# Altium schematic sheet BTT_M157_MOS_V0.3.SchDoc(BTT_M157_MOS_V0.3)
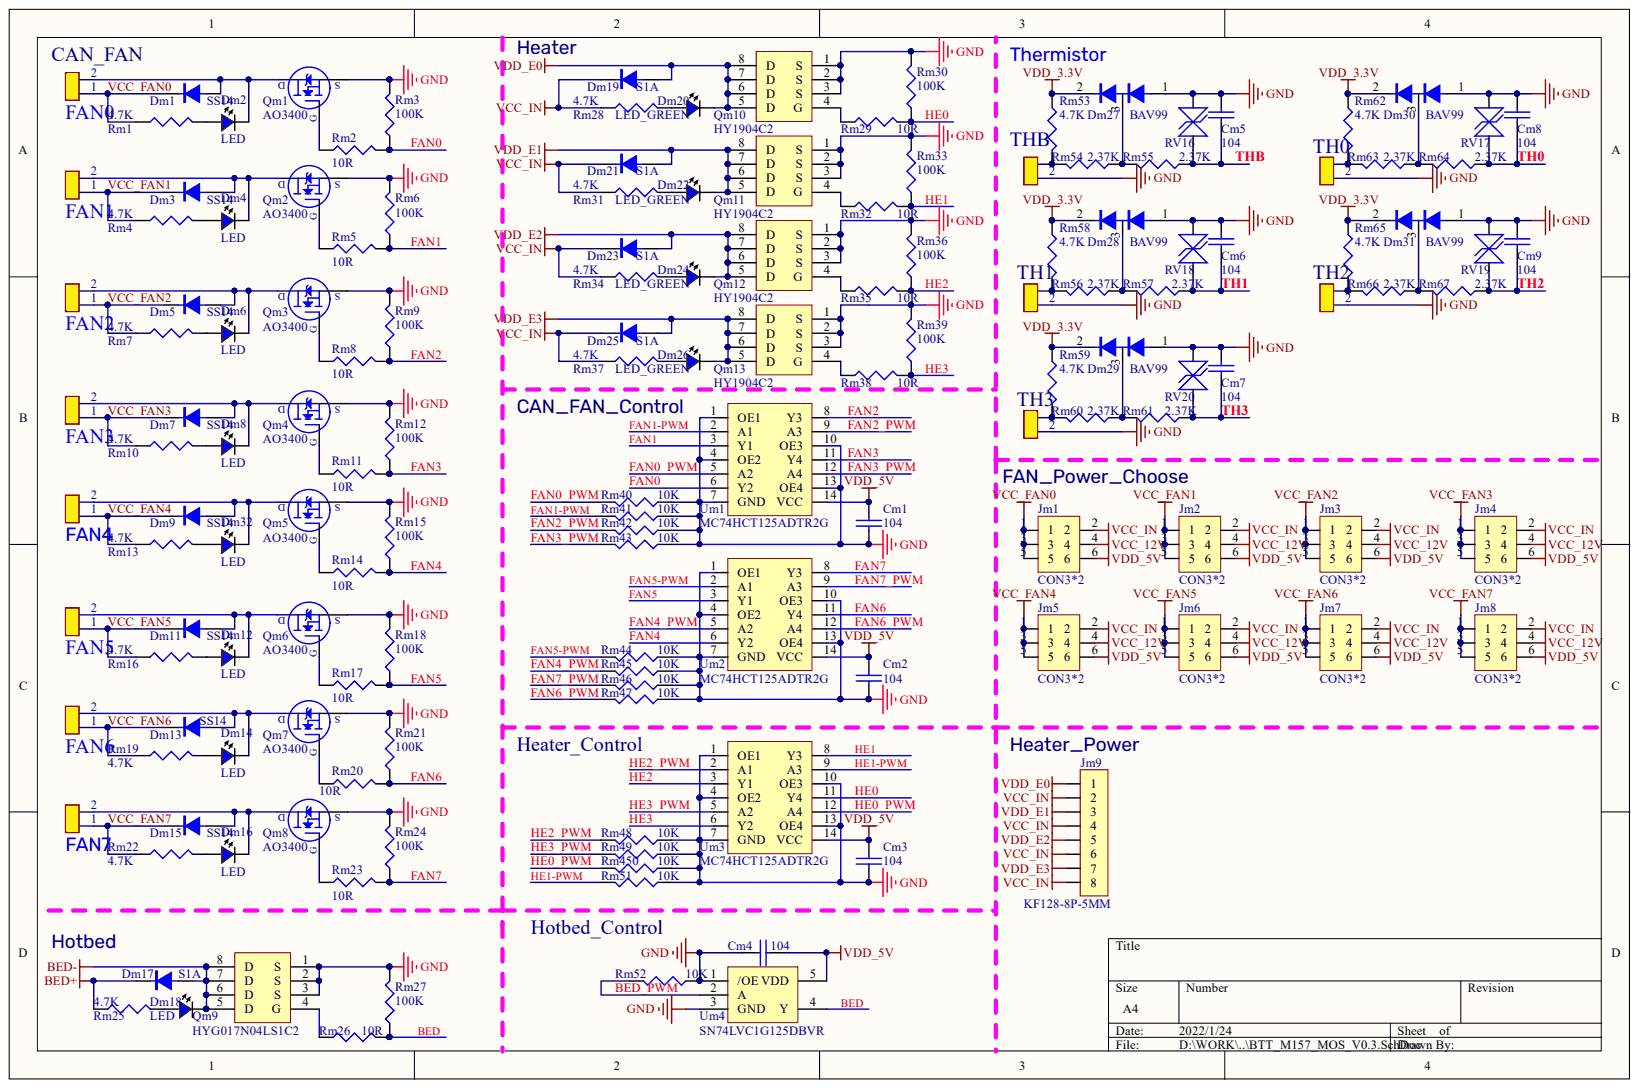
[diagram p0: BTT_M157_MOS_V0.3.SchDoc]

## Parts
Cm1  104  [0603c]
Cm2  104  [0603c]
Cm3  104  [0603c]
Cm4  104  [0603c]
Cm5  104  [0603c]
Cm6  104  [0603c]
Cm7  104  [0603c]
Cm8  104  [0603c]
Cm9  104  [0603c]
Dm1  SS14  [SOD-123FL]
Dm2  LED  [LED-0603-green]
Dm3  SS14  [SOD-123FL]
Dm4  LED  [LED-0603-green]
Dm5  SS14  [SOD-123FL]
Dm6  LED  [LED-0603-green]
Dm7  SS14  [SOD-123FL]
Dm8  LED  [LED-0603-green]
Dm9  SS14  [SOD-123FL]
Dm11  SS14  [SOD-123FL]
Dm12  LED  [LED-0603-green]
Dm13  SS14  [SOD-123FL]
Dm14  LED  [LED-0603-green]
Dm15  SS14  [SOD-123FL]
Dm16  LED  [LED-0603-green]
Dm17  S1A  [DO214AC(SMA)]
Dm18  LED  [LED-0603-green]
Dm19  S1A  [DO214AC(SMA)]
Dm20  LED_GREEN  [LED-0603-green]
Dm21  S1A  [DO214AC(SMA)]
Dm22  LED_GREEN  [LED-0603-green]
Dm23  S1A  [DO214AC(SMA)]
Dm24  LED_GREEN  [LED-0603-green]
Dm25  S1A  [DO214AC(SMA)]
Dm26  LED_GREEN  [LED-0603-green]
Dm27  BAV99  [sot-23A]
Dm28  BAV99  [sot-23A]
Dm29  BAV99  [sot-23A]
Dm30  BAV99  [sot-23A]
Dm31  BAV99  [sot-23A]
Dm32  LED  [LED-0603-green]
FAN0  XH2.54-2P-直针插座  [XH2.54-2P-直针插座]  (value not drawn)
FAN1  XH2.54-2P-直针插座  [XH2.54-2P-直针插座]  (value not drawn)
FAN2  XH2.54-2P-直针插座  [XH2.54-2P-直针插座]  (value not drawn)
FAN3  XH2.54-2P-直针插座  [XH2.54-2P-直针插座]  (value not drawn)
FAN4  XH2.54-2P-直针插座  [XH2.54-2P-直针插座]  (value not drawn)
FAN5  XH2.54-2P-直针插座  [XH2.54-2P-直针插座]  (value not drawn)
FAN6  XH2.54-2P-直针插座  [XH2.54-2P-直针插座]  (value not drawn)
FAN7  XH2.54-2P-直针插座  [XH2.54-2P-直针插座]  (value not drawn)
Jm1  CON3*2  [SIP3*2-2.54-BLACK]
Jm2  CON3*2  [SIP3*2-2.54-BLACK]
Jm3  CON3*2  [SIP3*2-2.54-BLACK]
Jm4  CON3*2  [SIP3*2-2.54-BLACK]
Jm5  CON3*2  [SIP3*2-2.54-BLACK]
Jm6  CON3*2  [SIP3*2-2.54-BLACK]
Jm7  CON3*2  [SIP3*2-2.54-BLACK]
Jm8  CON3*2  [SIP3*2-2.54-BLACK]
Jm9  KF128-8P-5MM  [KF128_8P_5.0MM]
Qm1  AO3400  [SOT-23-mos]
Qm2  AO3400  [SOT-23-mos]
Qm3  AO3400  [SOT-23-mos]
Qm4  AO3400  [SOT-23-mos]
Qm5  AO3400  [SOT-23-mos]
Qm6  AO3400  [SOT-23-mos]
Qm7  AO3400  [SOT-23-mos]
Qm8  AO3400  [SOT-23-mos]
Qm9  HYG017N04LS1C2  [DFN5*6-8]
Qm10  HY1904C2  [DFN5*6-8]
Qm11  HY1904C2  [DFN5*6-8]
Qm12  HY1904C2  [DFN5*6-8]
Qm13  HY1904C2  [DFN5*6-8]
Rm1  4.7K  [0603R]
Rm2  10R  [0603R]
Rm3  100K  [0603R]
Rm4  4.7K  [0603R]
Rm5  10R  [0603R]
Rm6  100K  [0603R]
Rm7  4.7K  [0603R]
Rm8  10R  [0603R]
Rm9  100K  [0603R]
Rm10  4.7K  [0603R]
Rm11  10R  [0603R]
Rm12  100K  [0603R]
Rm13  4.7K  [0603R]
Rm14  10R  [0603R]
Rm15  100K  [0603R]
Rm16  4.7K  [0603R]
Rm17  10R  [0603R]
Rm18  100K  [0603R]
Rm19  4.7K  [0603R]
Rm20  10R  [0603R]
Rm21  100K  [0603R]
Rm22  4.7K  [0603R]
Rm23  10R  [0603R]
Rm24  100K  [0603R]
Rm25  4.7K  [0603R]
Rm26  10R  [0603R]
Rm27  100K  [0603R]
Rm28  4.7K  [0603R]
Rm29  10R  [0603R]
Rm30  100K  [0603R]
Rm31  4.7K  [0603R]
Rm32  10R  [0603R]
Rm33  100K  [0603R]
Rm34  4.7K  [0603R]
Rm35  10R  [0603R]
Rm36  100K  [0603R]
Rm37  4.7K  [0603R]
Rm38  10R  [0603R]
Rm39  100K  [0603R]
Rm40  10K  [0603R]
Rm41  10K  [0603R]
Rm42  10K  [0603R]
Rm43  10K  [0603R]
Rm44  10K  [0603R]
Rm45  10K  [0603R]
Rm46  10K  [0603R]
Rm47  10K  [0603R]
Rm48  10K  [0603R]
Rm49  10K  [0603R]
Rm51  10K  [0603R]
Rm52  10K  [0603-R]
Rm53  4.7K  [0603R]
Rm54  2.37K  [0603R]
Rm55  2.37K  [0603R]
Rm56  2.37K  [0603R]
Rm57  2.37K  [0603R]
Rm58  4.7K  [0603R]
Rm59  4.7K  [0603R]
Rm60  2.37K  [0603R]
Rm61  2.37K  [0603R]
Rm62  4.7K  [0603R]
Rm63  2.37K  [0603R]
Rm64  2.37K  [0603R]
Rm65  4.7K  [0603R]
Rm66  2.37K  [0603R]
Rm67  2.37K  [0603R]
Rm450  10K  [0603R]
RV16  CG0603MLC-3.3LE  [0603L]  (value not drawn)
RV17  CG0603MLC-3.3LE  [0603L]  (value not drawn)
RV18  CG0603MLC-3.3LE  [0603L]  (value not drawn)
RV19  CG0603MLC-3.3LE  [0603L]  (value not drawn)
RV20  CG0603MLC-3.3LE  [0603L]  (value not drawn)
TH0  XH2.54-2P-直针插座  [XH2.54-2P-直针插座]  (value not drawn)
TH1  XH2.54-2P-直针插座  [XH2.54-2P-直针插座]  (value not drawn)
TH2  XH2.54-2P-直针插座  [XH2.54-2P-直针插座]  (value not drawn)
TH3  XH2.54-2P-直针插座  [XH2.54-2P-直针插座]  (value not drawn)
THB  XH2.54-2P-直针插座  [XH2.54-2P-直针插座]  (value not drawn)
Um1  MC74HCT125ADTR2G  [TSSOP14]
Um2  MC74HCT125ADTR2G  [TSSOP14]
Um3  MC74HCT125ADTR2G  [TSSOP14]
Um4  SN74LVC1G125DBVR  [sot23-5]

## Nets
BED+: Dm17.2 Rm25.1
BED-: Dm17.1 Dm18.K Qm9.5(D) Qm9.6(D) Qm9.7(D) Qm9.8(D)
GND: Cm1.1 Cm2.1 Cm3.1 Cm4.1 Cm5.2 Cm6.2 Cm7.2 Cm8.2 Cm9.2 Dm27.1 Dm28.1 Dm29.1 Dm30.1 Dm31.1 Qm1.2 Qm2.2 Qm3.2 Qm4.2 Qm5.2 Qm6.2 Qm7.2 Qm8.2 Qm9.1(S) Qm9.2(S) Qm9.3(S) Qm10.1(S) Qm10.2(S) Qm10.3(S) Qm11.1(S) Qm11.2(S) Qm11.3(S) Qm12.1(S) Qm12.2(S) Qm12.3(S) Qm13.1(S) Qm13.2(S) Qm13.3(S) Rm3.2 Rm6.2 Rm9.2 Rm12.2 Rm15.2 Rm18.2 Rm21.2 Rm24.2 Rm27.2 Rm30.2 Rm33.2 Rm36.2 Rm39.2 Rm40.1 Rm41.1 Rm42.1 Rm43.1 Rm44.1 Rm45.1 Rm46.1 Rm47.1 Rm48.1 Rm49.1 Rm51.1 Rm52.1 Rm450.1 RV16.1 RV17.1 RV18.1 RV19.1 RV20.1 TH0.2 TH1.2 TH2.2 TH3.2 THB.2 Um1.1(OE1) Um1.4(OE2) Um1.7(GND) Um1.10(OE3) Um1.13(OE4) Um2.1(OE1) Um2.4(OE2) Um2.7(GND) Um2.10(OE3) Um2.13(OE4) Um3.1(OE1) Um3.4(OE2) Um3.7(GND) Um3.10(OE3) Um3.13(OE4) Um4.1(/OE) Um4.3(GND)
VCC_12V: Jm1.4 Jm2.4 Jm3.4 Jm4.4 Jm5.4 Jm6.4 Jm7.4 Jm8.4
VCC_IN: Dm19.2 Dm21.2 Dm23.2 Dm25.2 Jm1.2 Jm2.2 Jm3.2 Jm4.2 Jm5.2 Jm6.2 Jm7.2 Jm8.2 Jm9.2 Jm9.4 Jm9.6 Jm9.8 Rm28.1 Rm31.1 Rm34.1 Rm37.1
VDD_3.3V: Dm27.2 Dm28.2 Dm29.2 Dm30.2 Dm31.2 Rm53.1 Rm58.1 Rm59.1 Rm62.1 Rm65.1
VDD_5V: Cm1.2 Cm2.2 Cm3.2 Cm4.2 Jm1.6 Jm2.6 Jm3.6 Jm4.6 Jm5.6 Jm6.6 Jm7.6 Jm8.6 Um1.14(VCC) Um2.14(VCC) Um3.14(VCC) Um4.5(VDD)
VDD_E0: Dm19.1 Dm20.K Jm9.1 Qm10.5(D) Qm10.6(D) Qm10.7(D) Qm10.8(D)
VDD_E1: Dm21.1 Dm22.K Jm9.3 Qm11.5(D) Qm11.6(D) Qm11.7(D) Qm11.8(D)
VDD_E2: Dm23.1 Dm24.K Jm9.5 Qm12.5(D) Qm12.6(D) Qm12.7(D) Qm12.8(D)
VDD_E3: Dm25.1 Dm26.K Jm9.7 Qm13.5(D) Qm13.6(D) Qm13.7(D) Qm13.8(D)
BED: Rm26.2 Rm27.1 Um4.4(Y)
BED_PWM: Rm52.2 Um4.2(A)
FAN0: Rm2.2 Rm3.1 Um1.6(Y2)
FAN0_PWM: Rm40.2 Um1.5(A2)
FAN1: Rm5.2 Rm6.1 Um1.3(Y1)
FAN1-PWM: Rm41.2 Um1.2(A1)
FAN2: Rm8.2 Rm9.1 Um1.8(Y3)
FAN2_PWM: Rm42.2 Um1.9(A3)
FAN3: Rm11.2 Rm12.1 Um1.11(Y4)
FAN3_PWM: Rm43.2 Um1.12(A4)
FAN4: Rm14.2 Rm15.1 Um2.6(Y2)
FAN4_PWM: Rm45.2 Um2.5(A2)
FAN5: Rm17.2 Rm18.1 Um2.3(Y1)
FAN5-PWM: Rm44.2 Um2.2(A1)
FAN6: Rm20.2 Rm21.1 Um2.11(Y4)
FAN6_PWM: Rm47.2 Um2.12(A4)
FAN7: Rm23.2 Rm24.1 Um2.8(Y3)
FAN7_PWM: Rm46.2 Um2.9(A3)
HE0: Rm29.2 Rm30.1 Um3.11(Y4)
HE0_PWM: Rm450.2 Um3.12(A4)
HE1: Rm32.2 Rm33.1 Um3.8(Y3)
HE1-PWM: Rm51.2 Um3.9(A3)
HE2: Rm35.2 Rm36.1 Um3.3(Y1)
HE2_PWM: Rm48.2 Um3.2(A1)
HE3: Rm38.2 Rm39.1 Um3.6(Y2)
HE3_PWM: Rm49.2 Um3.5(A2)
TH0: Cm8.1 Rm64.1 RV17.2
TH1: Cm6.1 Rm57.1 RV18.2
TH2: Cm9.1 Rm67.1 RV19.2
TH3: Cm7.1 Rm61.1 RV20.2
THB: Cm5.1 Rm55.1 RV16.2
VCC_FAN0: Dm1.2 FAN0.1 Jm1.1 Jm1.3 Jm1.5 Rm1.1
VCC_FAN1: Dm3.2 FAN1.1 Jm2.1 Jm2.3 Jm2.5 Rm4.1
VCC_FAN2: Dm5.2 FAN2.1 Jm3.1 Jm3.3 Jm3.5 Rm7.1
VCC_FAN3: Dm7.2 FAN3.1 Jm4.1 Jm4.3 Jm4.5 Rm10.1
VCC_FAN4: Dm9.2 FAN4.1 Jm5.1 Jm5.3 Jm5.5 Rm13.1
VCC_FAN5: Dm11.2 FAN5.1 Jm6.1 Jm6.3 Jm6.5 Rm16.1
VCC_FAN6: Dm13.2 FAN6.1 Jm7.1 Jm7.3 Jm7.5 Rm19.1
VCC_FAN7: Dm15.2 FAN7.1 Jm8.1 Jm8.3 Jm8.5 Rm22.1
NetDm1_1: Dm1.1 Dm2.K FAN0.2 Qm1.3
NetDm2_A: Dm2.A Rm1.2
NetDm3_1: Dm3.1 Dm4.K FAN1.2 Qm2.3
NetDm4_A: Dm4.A Rm4.2
NetDm5_1: Dm5.1 Dm6.K FAN2.2 Qm3.3
NetDm6_A: Dm6.A Rm7.2
NetDm7_1: Dm7.1 Dm8.K FAN3.2 Qm4.3
NetDm8_A: Dm8.A Rm10.2
NetDm9_1: Dm9.1 Dm32.K FAN4.2 Qm5.3
NetDm11_1: Dm11.1 Dm12.K FAN5.2 Qm6.3
NetDm12_A: Dm12.A Rm16.2
NetDm13_1: Dm13.1 Dm14.K FAN6.2 Qm7.3
NetDm14_A: Dm14.A Rm19.2
NetDm15_1: Dm15.1 Dm16.K FAN7.2 Qm8.3
NetDm16_A: Dm16.A Rm22.2
NetDm18_A: Dm18.A Rm25.2
NetDm20_A: Dm20.A Rm28.2
NetDm22_A: Dm22.A Rm31.2
NetDm24_A: Dm24.A Rm34.2
NetDm26_A: Dm26.A Rm37.2
NetDm27_3: Dm27.3 Rm54.1 Rm55.2
NetDm28_3: Dm28.3 Rm56.1 Rm57.2
NetDm29_3: Dm29.3 Rm60.1 Rm61.2
NetDm30_3: Dm30.3 Rm63.1 Rm64.2
NetDm31_3: Dm31.3 Rm66.1 Rm67.2
NetDm32_A: Dm32.A Rm13.2
NetQm1_1: Qm1.1 Rm2.1
NetQm2_1: Qm2.1 Rm5.1
NetQm3_1: Qm3.1 Rm8.1
NetQm4_1: Qm4.1 Rm11.1
NetQm5_1: Qm5.1 Rm14.1
NetQm6_1: Qm6.1 Rm17.1
NetQm7_1: Qm7.1 Rm20.1
NetQm8_1: Qm8.1 Rm23.1
NetQm9_4: Qm9.4(G) Rm26.1
NetQm10_4: Qm10.4(G) Rm29.1
NetQm11_4: Qm11.4(G) Rm32.1
NetQm12_4: Qm12.4(G) Rm35.1
NetQm13_4: Qm13.4(G) Rm38.1
NetRm53_2: Rm53.2 Rm54.2 THB.1
NetRm56_2: Rm56.2 Rm58.2 TH1.1
NetRm59_2: Rm59.2 Rm60.2 TH3.1
NetRm62_2: Rm62.2 Rm63.2 TH0.1
NetRm65_2: Rm65.2 Rm66.2 TH2.1

## Library data of the parts
Cm1-Cm9 = CAP: Capacitor; Component Kind: Standard; Library Name: My Useful Discrete Devices.SchLib
Dm1 Dm3 Dm5 Dm7 Dm9 Dm11 Dm13 Dm15 Dm17 Dm19 Dm21 Dm23 Dm25 = DIODE: Component Kind: Standard; Library Name: 常用库.SCHLIB
Dm2 Dm4 Dm6 Dm8 Dm12 Dm14 Dm16 Dm18 Dm32 = LED_1: Component Kind: Standard; Library Name: My Useful Discrete Devices.SchLib
Dm20 Dm22 Dm24 Dm26 = LED: Component Kind: Standard; Library Name: 常用库.SCHLIB
FAN0-FAN7 TH0-TH3 THB = SIP2: Component Kind: Standard; Library Name: liroqi.SchLib
Jm9 = CON8: Connector; Component Kind: Standard; Library Name: My Useful Discrete Devices.SchLib
Qm1-Qm8 = NMOS: Component Kind: Standard; Library Name: My Useful Discrete Devices.SchLib
Qm9-Qm13 = WSD40120DN56G: Component Kind: Standard; Library Name: My Useful IC.SchLib
Rm1-Rm49 Rm51-Rm67 Rm450 = RES1: Component Kind: Standard
  Rm1-Rm27 Rm40-Rm49 Rm51 Rm53-Rm67 Rm450: Library Name: My Useful Discrete Devices.SchLib
  Rm28-Rm39: Library Name: 常用库.SCHLIB
  Rm52: Library Name: My Usefu Discrete Devices.SchLib
RV16-RV20 = MLC-3.3LE: Component Kind: Standard; Library Name: 常用元器件.SchLib
Um4 = SN74LVC1G125DBVR: 单路缓冲器; Component Kind: Standard; Library Name: My Useful IC.SchLib
Dm27-Dm31 = BAV99: Component Kind: Standard; Library Name: 常用库.SCHLIB
Jm1-Jm8 = CON3*2: Component Kind: Standard; Library Name: My Useful Discrete Devices.SchLib
Um1-Um3 = MC74HCT125ADTR2G: Component Kind: Standard; Description: MC74HCT125ADTR2G; Library Name: IC.SchLib
